# Altium schematic sheet Connectors.SchDoc(Connectors)
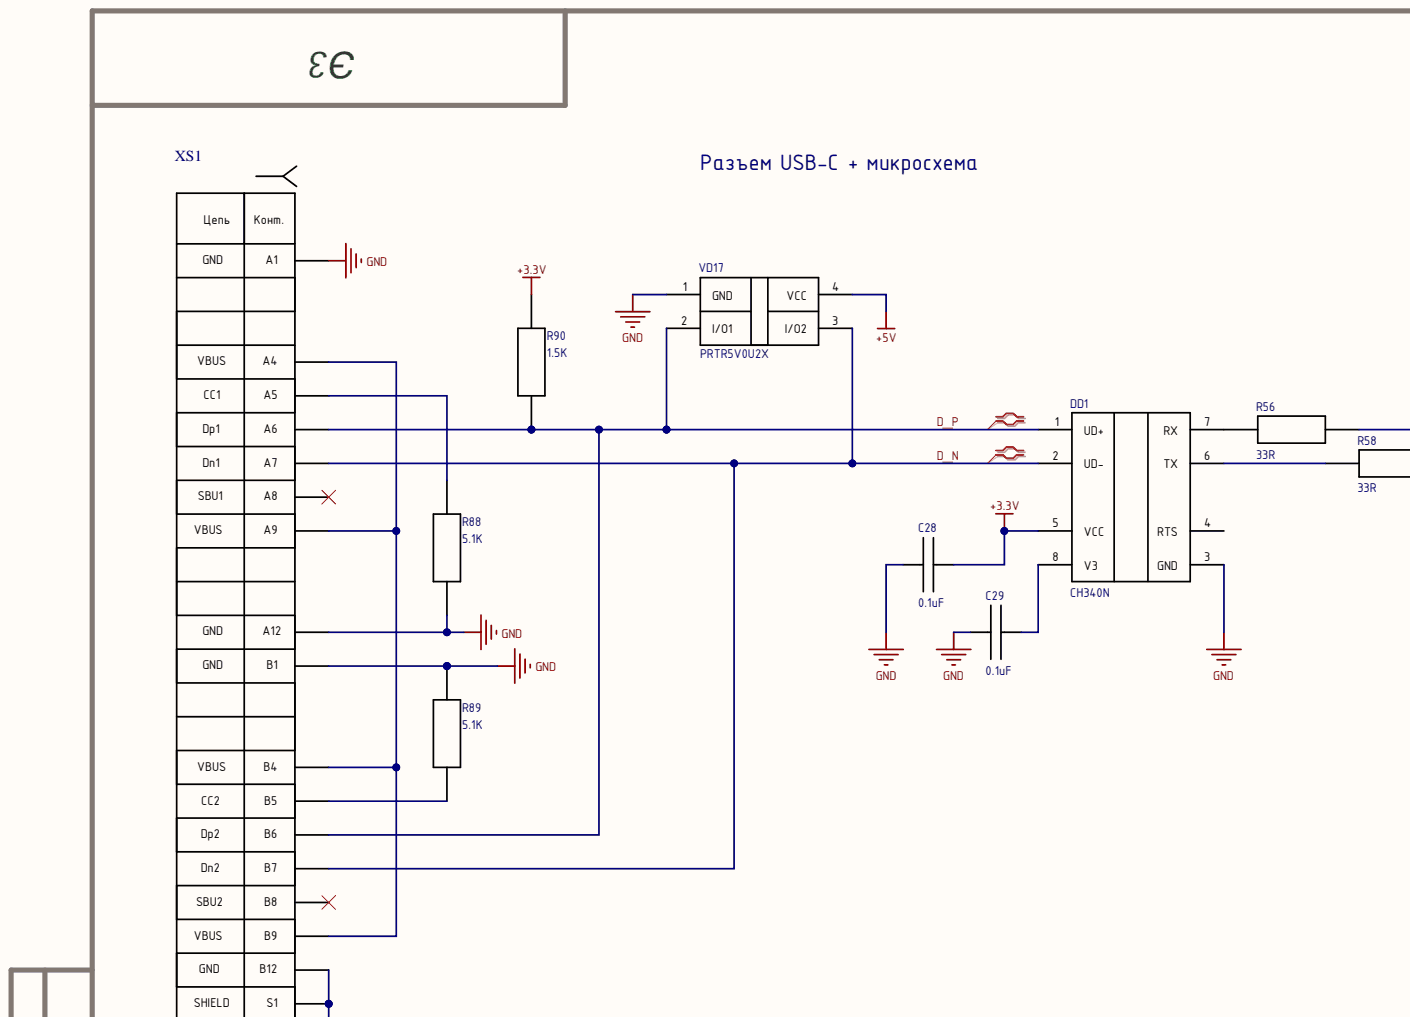
[diagram p0: Connectors.SchDoc, top left]
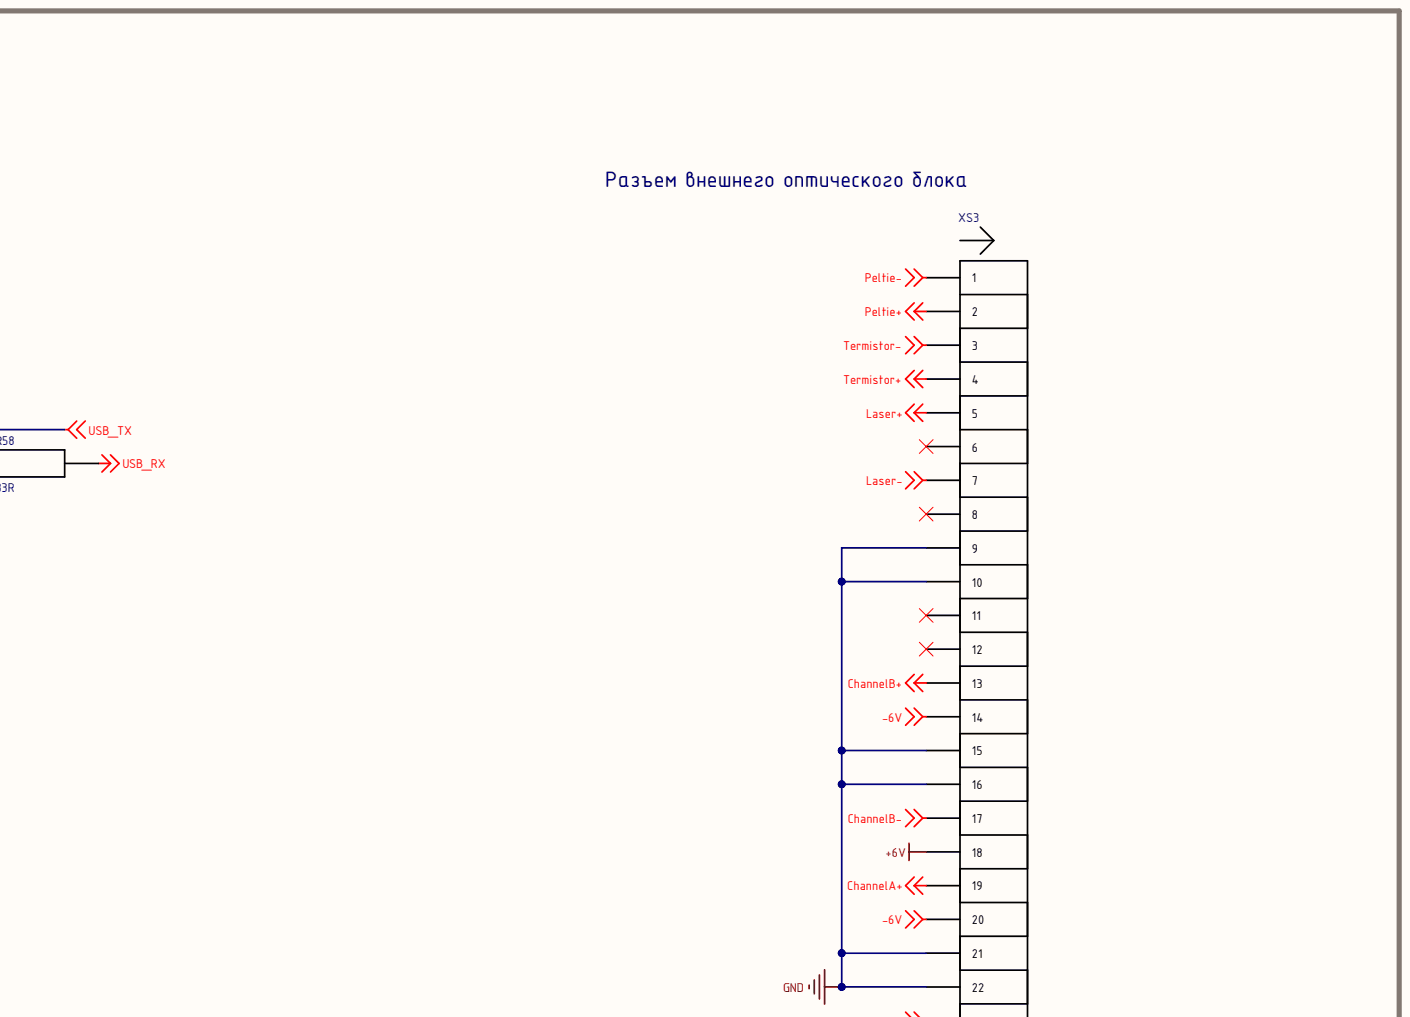
[diagram p1: Connectors.SchDoc, top right]
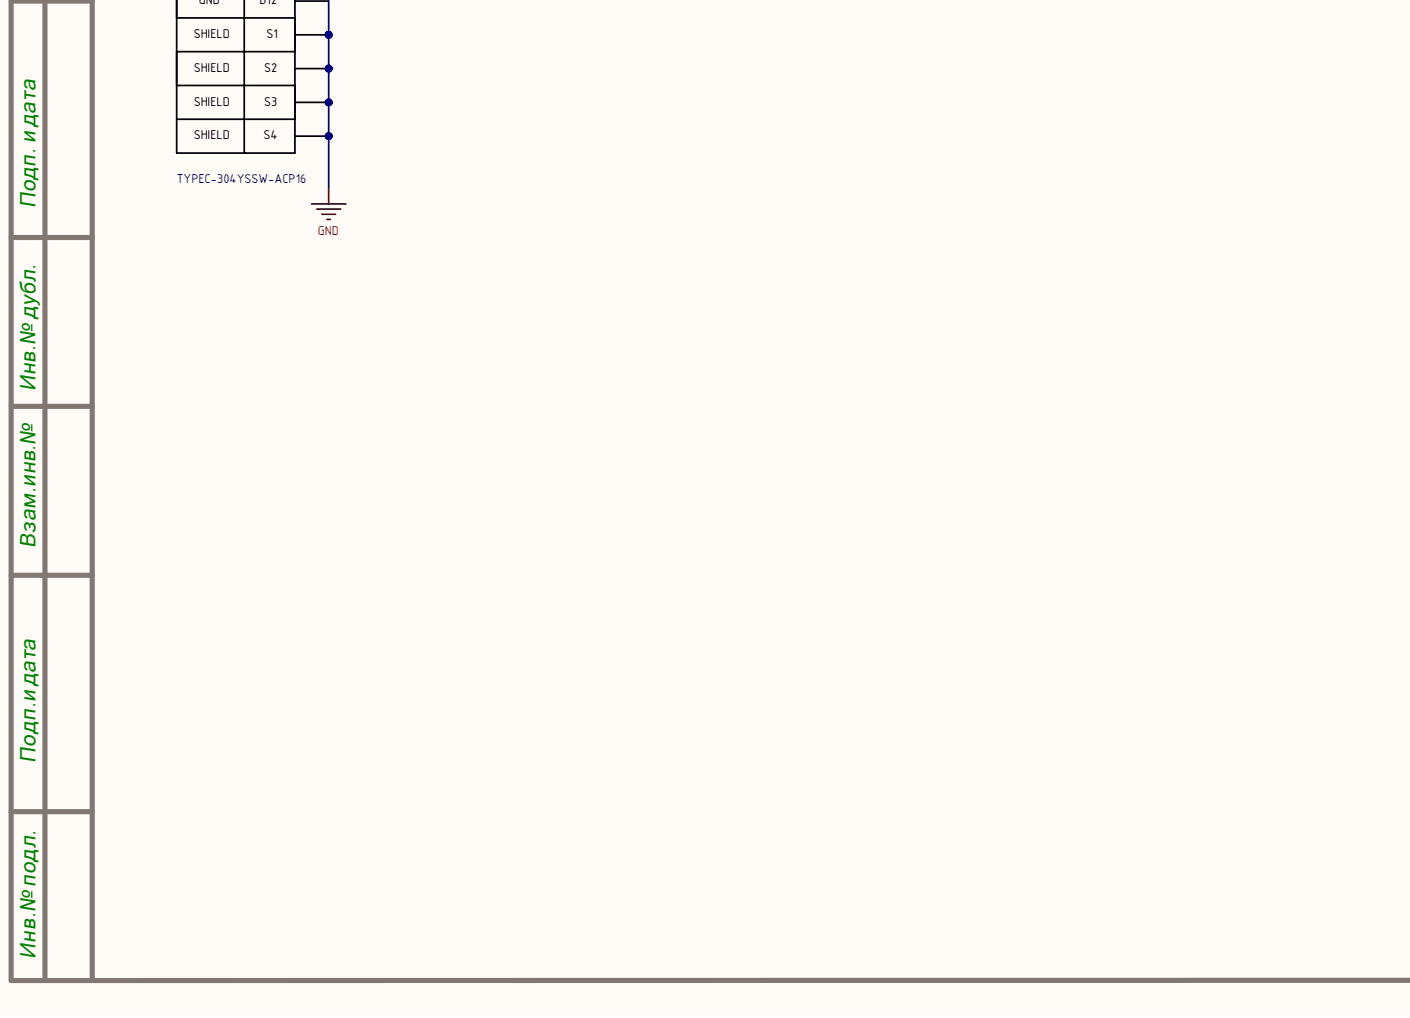
[diagram p2: Connectors.SchDoc, bottom left]
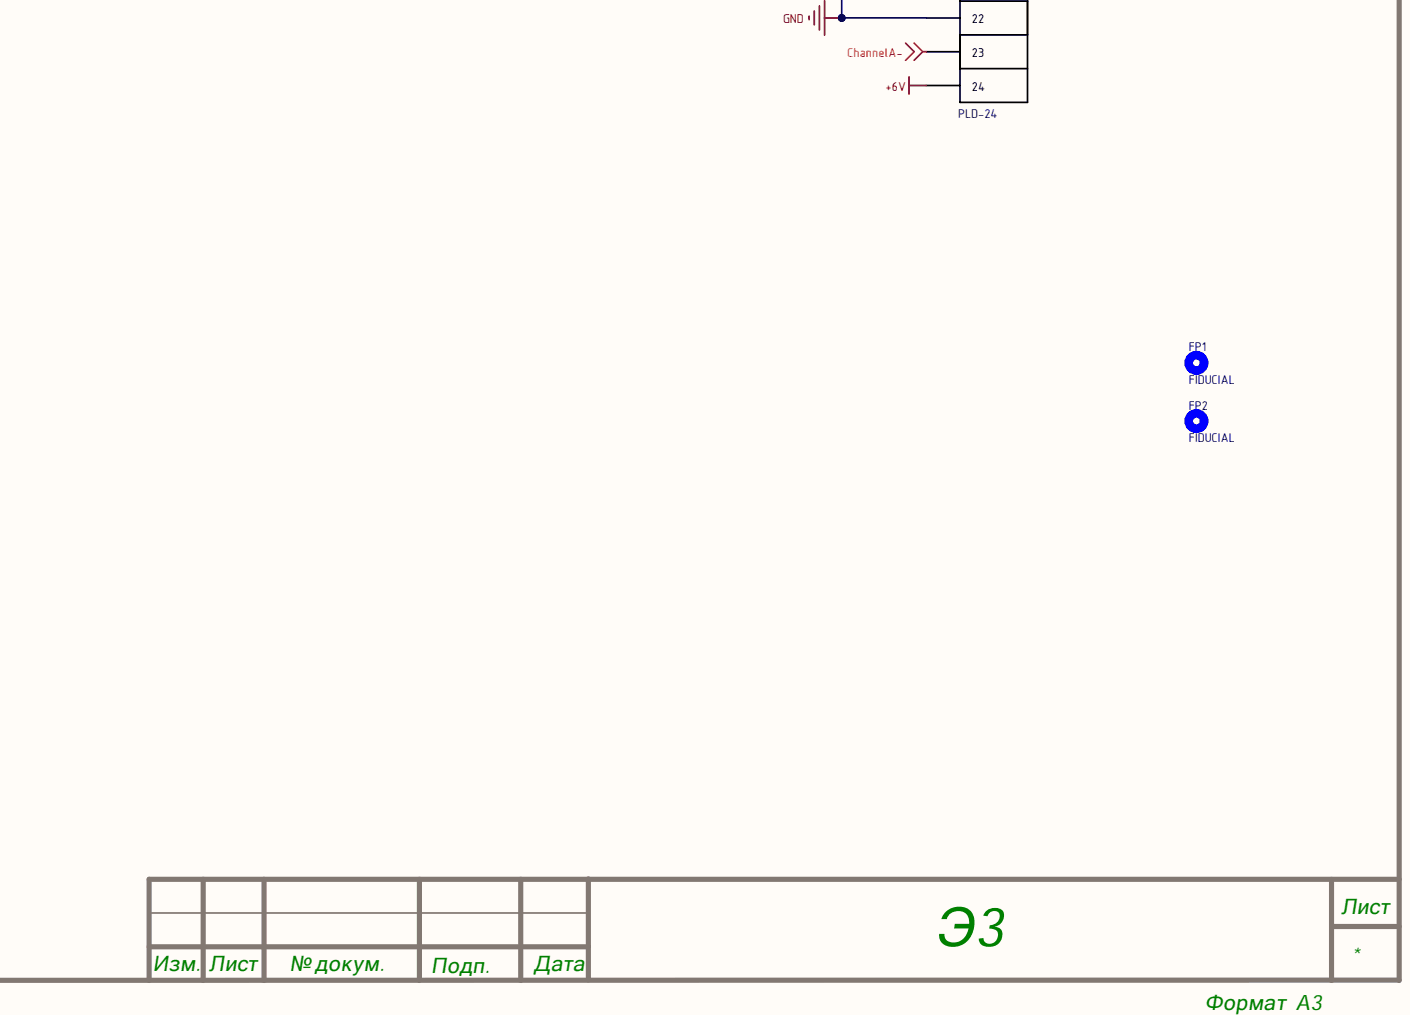
[diagram p3: Connectors.SchDoc, bottom right]

## Parts
C28  0.1uF  [0603C]
C29  0.1uF  [0603C]
DD1  CH340N  [SOP8]
FP1  FIDUCIAL  [FIDICIAL POINT - R0.3]
FP2  FIDUCIAL  [FIDICIAL POINT - R0.3]
R56  33R  [R0402]
R58  33R  [R0402]
R88  5.1K  [R0402]
R89  5.1K  [R0402]
R90  1.5K  [R0402]
VD17  PRTR5V0U2X  [SOT143B]
XS1  TYPEC-304YSSW-ACP16  [USB-C 16P]
XS3  PLD-24  [PLD-24]

## Nets
+3.3V: C28.2 DD1.5(VCC) R90.2
+5V: VD17.4(VCC)
+6V: XS3.18 XS3.24
-6V: XS3.14 XS3.20
ChannelA+: XS3.19
ChannelA-: XS3.23
ChannelB+: XS3.13
ChannelB-: XS3.17
GND: C28.1 C29.1 DD1.3(GND) R88.1 R89.2 VD17.1(GND) XS1.A1/B12(B12) XS1.A12/B1(B1) XS1.S1 XS1.S2 XS1.S3 XS1.S4 XS3.9 XS3.10 XS3.15 XS3.16 XS3.21 XS3.22
Laser+: XS3.5
Laser-: XS3.7
Peltie+: XS3.2
Peltie-: XS3.1
Termistor+: XS3.4
Termistor-: XS3.3
USB_RX: R58.2
USB_TX: R56.2
D_N: DD1.2(UD-) VD17.3(I/O2) XS1.A7 XS1.B7
D_P: DD1.1(UD+) R90.1 VD17.2(I/O1) XS1.A6 XS1.B6
NetC29_2: C29.2 DD1.8(V3)
NetDD1_6: DD1.6(TX) R58.1
NetDD1_7: DD1.7(RX) R56.1
NetR88_2: R88.2 XS1.A5
NetR89_1: R89.1 XS1.B5
NetXS1_A4/B9: XS1.A4/B9(B9) XS1.A9/B4(B4)

Not connected: DD1 4(RTS); XS1 A8 B8; XS3 6 8 11 12

## Library data of the parts
C28 C29 = C0603-0.1UF 10% 50V: C0603 0.1UF 10% 50V; Part Number: SMD CAP CER 0.1UF ±10% 50V X7R 0603; Added_by: anton@teslatec.ru; Pkg: 0603; Solder_Amount: 2; Component Kind: Standard; Created_at: 10/01/2023; Mount: MOUNT; MountType: SMD; Library Name: Test_Stand_Pergam.SchLib
DD1 = CH340N: USB to Serial Port Chip CH340, SOP-8; Added_by: irdolgopolowa@yandex.ru; Pkg: SOIC-8; Solder_Amount: 8; Component Kind: Standard; Created_at: 29/10/24; Mount: MOUNT; MountType: SMD; Part Number: CH340N; Library Name: Test_Stand_Pergam.SchLib
FP1 FP2 = FIDUCIAL_R0.3: Adder_by: ridel_av@teslatec.ru; Component Kind: Standard; Created_at: 22/03/2020; Description: FIDUCIAL; Mount: -; MountType: -; Pkg: -; Solder_Amount: -; Library Name: Test_Stand_Pergam.SchLib
R56 R58 = R0402-33R: Resistor SMD 33R 1% 0402; Part Number: SMD RES 33R OHM 1% ±100ppm/°C 0402; Added_by: Rutel@teslatec.ru; Pkg: 0402; Solder_Amount: 2; Component Kind: Standard; Created_at: 21/04/2020; Mount: MOUNT; MountType: SMD; Library Name: Test_Stand_Pergam.SchLib
R88 R89 = R0402-5.1K: Resistor SMD 5.1K 1% 0402; Part Number: SMD RES 5.1 KOHM 1% ±100ppm/°C 0402; Added_by: Rutel@teslatec.ru; Pkg: 0402; Solder_Amount: 2; Component Kind: Standard; Created_at: 21/04/2020; Mount: MOUNT; MountType: SMD; Library Name: Test_Stand_Pergam.SchLib
R90 = R0402-1.5K: Resistor SMD 1.5K 1% 0402; Part Number: SMD RES 1.5 KOHM 1% ±100ppm/°C 0402; Added_by: Rutel@teslatec.ru; Pkg: 0402; Solder_Amount: 2; Component Kind: Standard; Created_at: 21/04/2020; Mount: MOUNT; MountType: SMD; Library Name: Test_Stand_Pergam.SchLib
VD17 = PRTR5V0U2X: PRTR5V0U2X, ESD(Rail-to-Rail), 1pF,  5V; Added_by: irdolgopolowa@yandex.ru; Pkg: SOT-143; Solder_Amount: 4; Component Kind: Standard; Created_at: 29/10/24; Mount: MOUNT; MountType: SMD; Part Number: PRTR5V0U2X; Library Name: Test_Stand_Pergam.SchLib
XS1 = TYPEC-304YSSW-ACP16: USB Type-C, 16 Pin; Added_by: ridel_av@teslatec.ru; Solder_Amount: 16; Component Kind: Standard; Created_at: 13/12/2021; Mount: MOUNT; MountType: SMD; Part Number: TYPEC-304YSSW-ACP16; Pkg: TYPEC-304YSSW-ACP16; Library Name: Test_Stand_Pergam.SchLib
XS3 = PLD-24: Pin Header Pitch 2.54mm; Added_by: andrew@teslatec.ru; Pkg: PLD-24 2.54mm; Solder_Amount: 24; Cable/Wire: -; Component Kind: Standard; Created_at: 1/09/2020; Mating Part: -; Mating Terminals: -; Mount: MOUNT; MountType: THM; Part Number: PLD-24; Library Name: Test_Stand_Pergam.SchLib
